# Altium schematic sheet SIB ATM328P.SchDoc
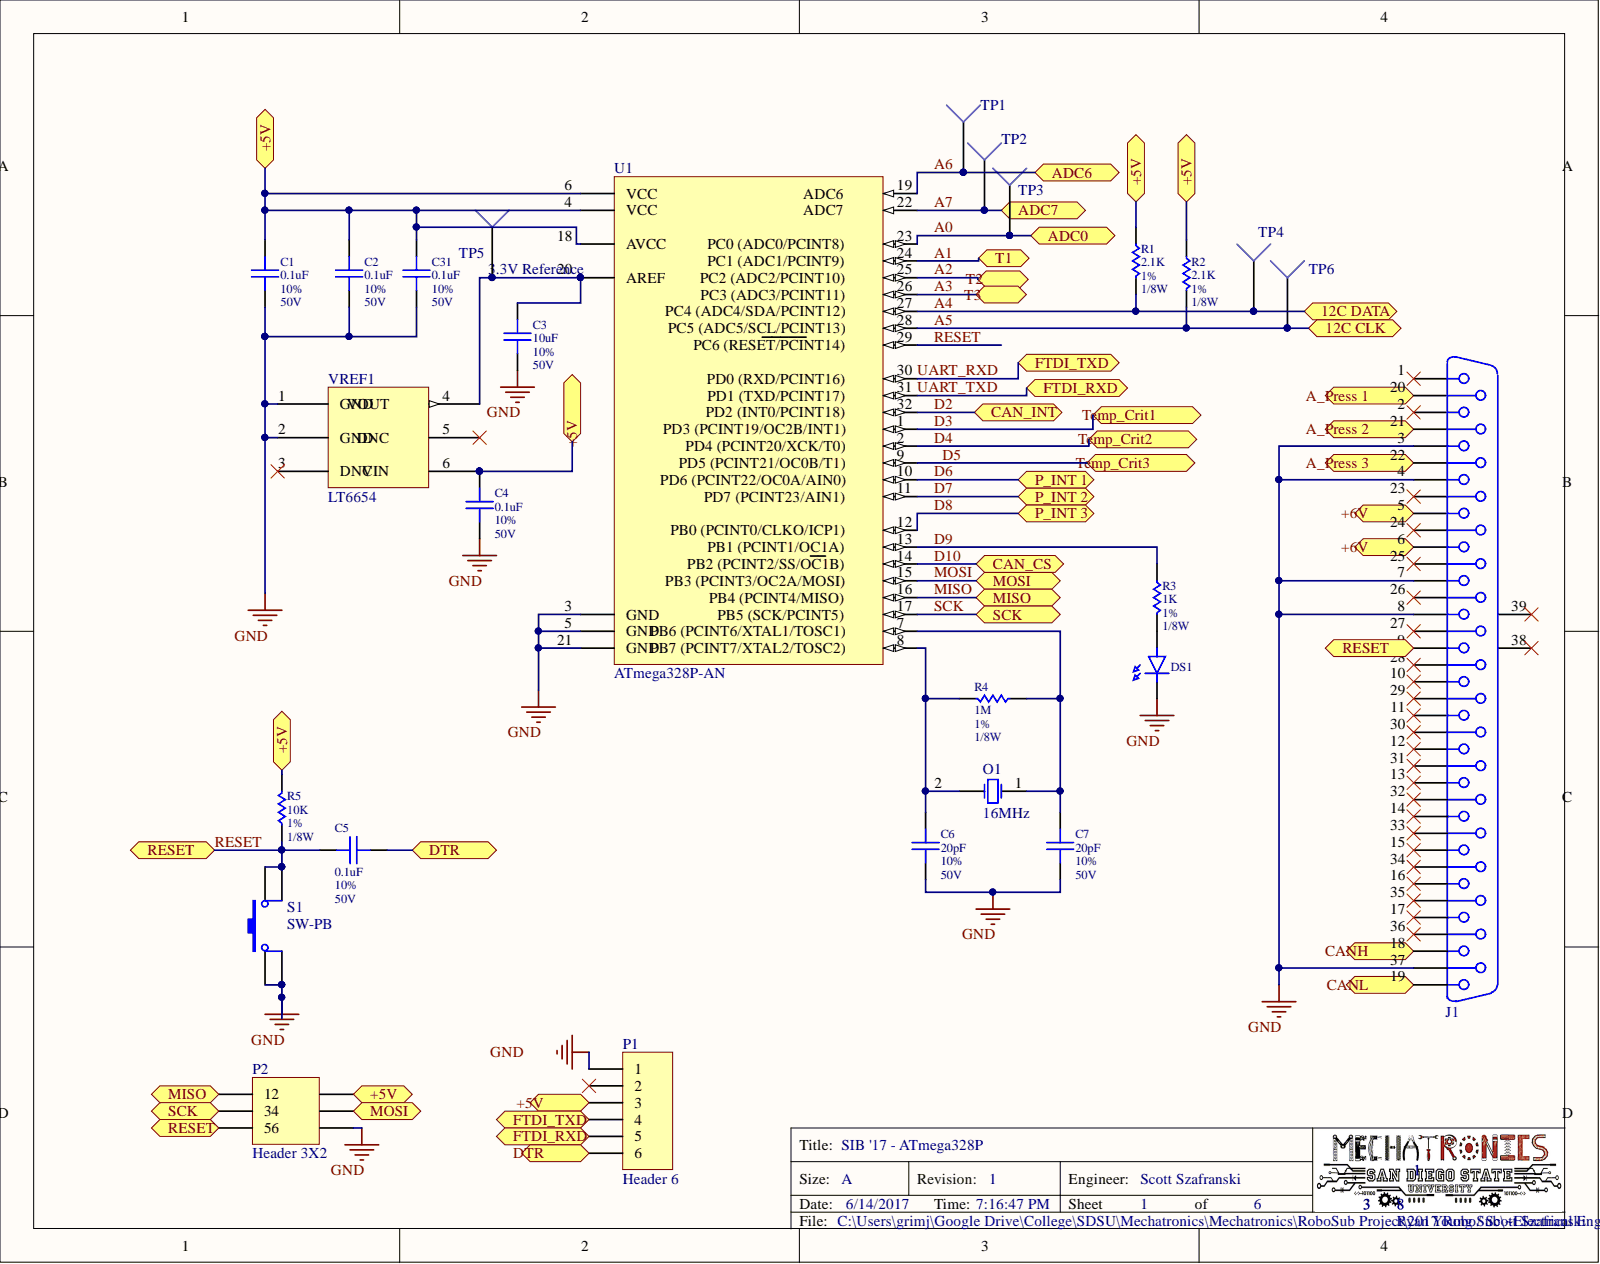
[diagram p0: SIB ATM328P.SchDoc]

## Parts
C1  0.1uF  Tolerance: 10%  Voltage: 50V  [Component 0805]
C2  0.1uF  Tolerance: 10%  Voltage: 50V  [Component 0805]
C3  10uF  Tolerance: 10%  Voltage: 50V  [Component 0805]
C4  0.1uF  Tolerance: 10%  Voltage: 50V  [Component 0805]
C5  0.1uF  Tolerance: 10%  [Component 0805]
C6  20pF  Tolerance: 10%  Voltage: 50V  [Component 0805]
C7  20pF  Tolerance: 10%  Voltage: 50V  [Component 0805]
C31  Capacitance: 0.1uF  Tolerance: 10%  Voltage: 50V  [Component 0805]  (hidden comment: Ceramic Capacitor)
DS1  LED, Red  [LED RED 0805]  (value not drawn)
J1  D-SUB 37-Pin  [DSUB37RightAngle]  (value not drawn)
O1  freq: 16MHz  [HC/49US]  (hidden comment: Crystal Oscillator)
P1  Header 6  [HDR1X6]
P2  Header 3X2  [HDR2X3]
R1  2.1K  Power: 1/8W  Tolerance: 1%  [Component 0805]
R2  2.1K  Power: 1/8W  Tolerance: 1%  [Component 0805]
R3  1K  Power: 1/8W  Tolerance: 1%  [Component 0805]
R4  1M  Tolerance: 1%  [Component 0805]
R5  10K  Power: 1/8W  Tolerance: 1%  [Component 0805]
S1  SW-PB  [Push Button Reel]
TP1  Test Point  [Test Point]  (value not drawn)
TP2  Test Point  [Test Point]  (value not drawn)
TP3  Test Point  [Test Point]  (value not drawn)
TP4  Test Point  [Test Point]  (value not drawn)
TP5  Test Point  [Test Point]  (value not drawn)
TP6  Test Point  [Test Point]  (value not drawn)
U1  ATmega328P-AN  [32A_N]
VREF1  LT6654

## Ports
12C CLK, 12C DATA, +5V, +6V, A_PRESS 1, A_PRESS 2, A_PRESS 3, ADC0, ADC6, ADC7, CAN_CS, CAN_INT, CANH, CANL, DTR, FTDI_RXD, FTDI_TXD, MISO, MOSI, P_INT 1, P_INT 2, P_INT 3, RESET, SCK, T1, T2, T3, TEMP_CRIT1, TEMP_CRIT2, TEMP_CRIT3

## Nets
GND: C1.2 C2.2 C3.2 C4.2 C6.2 C7.2 C31.2 DS1.1 J1.3 J1.4 J1.7 J1.8 J1.37 P1.1 P2.6 S1.1 S1.3 U1.3(GND) U1.5(GND) U1.21(GND) VREF1.1(GND) VREF1.2(GND)
+5V: C1.1 C2.1 C4.1 C31.1 P1.3 P2.2 R1.1 R2.1 R5.2 U1.4(VCC) U1.6(VCC) U1.18(AVCC) VREF1.6 ; port +5V
+6V: J1.5 J1.6 ; port +6V
A0: TP3.1 U1.23 ; port ADC0
A1: U1.24 ; port T1
A2: U1.25 ; port T2
A3: U1.26 ; port T3
A4: R1.2 TP4.1 U1.27 ; port 12C DATA
A5: R2.2 TP6.1 U1.28 ; port 12C CLK
A6: TP1.1 U1.19 ; port ADC6
A7: TP2.1 U1.22 ; port ADC7
CANH: J1.18 ; port CANH
CANL: J1.19 ; port CANL
D2: U1.32 ; port CAN_INT
D3: U1.1 ; port TEMP_CRIT1
D4: U1.2 ; port TEMP_CRIT2
D5: U1.9 ; port TEMP_CRIT3
D6: U1.10 ; port P_INT 1
D7: U1.11 ; port P_INT 2
D8: U1.12 ; port P_INT 3
D9: R3.1 U1.13
D10: U1.14 ; port CAN_CS
DTR: C5.2 P1.6 ; port DTR
MISO: P2.1 U1.16 ; port MISO
MOSI: P2.4 U1.15 ; port MOSI
RESET: C5.1 J1.9 P2.5 R5.1 S1.2 S1.4 U1.29 ; port RESET
SCK: P2.3 U1.17 ; port SCK
UART_RXD: P1.4 U1.30 ; port FTDI_TXD
UART_TXD: P1.5 U1.31 ; port FTDI_RXD
NetC3_1: C3.1 TP5.1 U1.20(AREF) VREF1.4
NetC6_1: C6.1 O1.2 R4.2 U1.8
NetC7_1: C7.1 O1.1 R4.1 U1.7
NetDS1_2: DS1.2 R3.2
NetJ1_20: J1.20 ; port A_PRESS 1
NetJ1_21: J1.21 ; port A_PRESS 2
NetJ1_22: J1.22 ; port A_PRESS 3

Not connected: J1 1 2 10 11 12 13 14 15 16 17 23 24 25 26 27 28 29 30 31 32 33 34 35 36 38 39; P1 2; VREF1 3(DNC) 5

## Library data of the parts
C1-C4 C6 C7 C31 = Capacitor, Ceramic: Component Kind: Standard; Library Name: MechatronicsSCH.SchLib
  C1 C2 C4: Capacitance: 0.1uF
  C3: Capacitance: 10uF
  C6 C7: Capacitance: 20pF
C5 = Capacitor, Ceramic: Voltage: 50V; Capacitance: 0.1uF; Component Kind: Standard; Library Name: MechatronicsSCH.SchLib
J1 = 37-Pin D-Sub: Component Kind: Standard; Description: D-SUB 37-Pin; Library Name: Dsub37RightAngle.SchLib
P1 = Header 6: Header, 6-Pin; Component Kind: Standard; LatestRevisionDate: 17-Jul-2002; LatestRevisionNote: Re-released for DXP Platform.; Library Name: Miscellaneous Connectors.IntLib; Signal Integrity: Connector; Publisher: Altium Limited
P2 = Header 3X2: Header, 3-Pin, Dual row; Component Kind: Standard; LatestRevisionDate: 17-Jul-2002; LatestRevisionNote: Re-released for DXP Platform.; Library Name: Miscellaneous Connectors.IntLib; Signal Integrity: Connector; Publisher: Altium Limited
R1-R3 R5 = Resistor: Thick Film Resistors - SMD 1/16watt 1%; Component Kind: Standard; Library Name: MechatronicsSCH.SchLib
  R1 R2: Resistance: 2.1K
  R3: Resistance: 1K
  R5: Resistance: 10K
R4 = Resistor: Thick Film Resistors - SMD 1/16watt 1%; Power: 1/8W; Component Kind: Standard; Resistance: 1M; Library Name: MechatronicsSCH.SchLib
S1 = SW-PB: Switch; Component Kind: Standard; LatestRevisionDate: 17-Jul-2002; LatestRevisionNote: Re-released for DXP Platform.; Library Name: Push Button.SchLib; PackageDescription: Switch; 2 Leads; PackageReference: SPST-2; Published: 24-Mar-1999; Publisher: Altium Limited
U1 = ATmega328P-AN: 8-bit AVR Microcontroller, 32KB Flash, 1KB EEPROM, 2KB SRAM, 32-pin TQFP, Industrial Grade (-40°C to 105°C); Code_JEDEC: MS-026-ABA; Component Kind: Standard; ComponentLink1Description: Manufacturer URL; ComponentLink1URL: http://www.atmel.com/; ComponentLink2Description: ATmega48A/48PA/88A/88PA/168A/168PA/328/328P Datasheet; ComponentLink2URL: http://www.atmel.com/dyn/resources/prod_documents/8271.pdf; ComponentLink3Description: ATmega48A/48PA/88A/88PA/168A/168PA/328/328P Summary; ComponentLink3URL: http://www.atmel.com/dyn/resources/prod_documents/8271S.pdf; DatasheetVersion: revD, May-2011; Library Name: Atmel Microcontroller megaAVR-1.IntLib; PackageDescription: 32-Lead, 7x7x1.0 mm Body, 0.8mm Lead Pitch, Thin Profile Plastic Quad Flat Package (TQFP); PackageReference: 32A; PackageVersion: revC, Oct-2010
VREF1 = LT6654: 3.3V Reference - LT6654AHS6-3.3; Component Kind: Standard; Library Name: SIB_17_Sch_Lib.SchLib
DS1 = LED, Red: Component Kind: Standard; Library Name: MechatronicsSCH.SchLib
O1 = Crystal Oscillator: Component Kind: Standard; Library Name: MechatronicsSCH.SchLib
TP1-TP6 = Test Point: Component Kind: Standard; Library Name: RyanY-Integrated_Library.IntLib
